# Altium schematic sheet FE.SchDoc
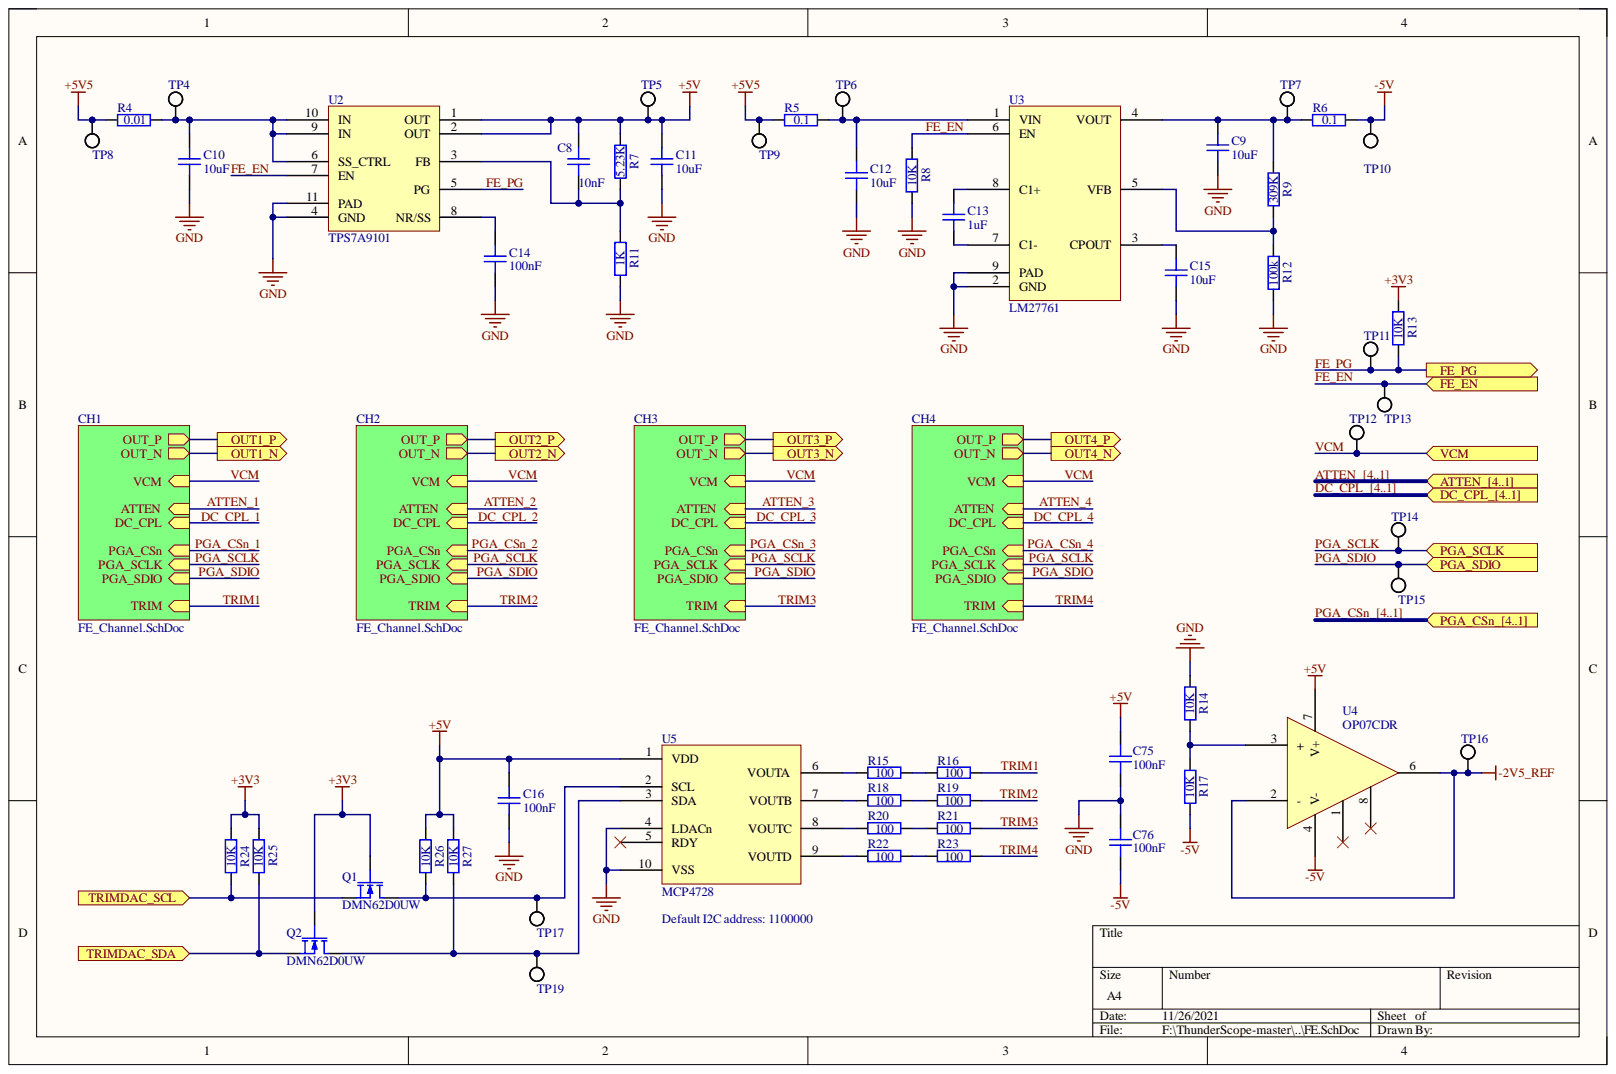
[diagram p0: FE.SchDoc]

## Parts
C8  10nF  [GEN_C_0402]
C9  10uF  [GEN_C_0603]
C10  10uF  [GEN_C_0603]
C11  10uF  [GEN_C_0603]
C12  10uF  [GEN_C_0603]
C13  1uF  [GEN_C_0402]
C14  100nF  [GEN_C_0402]
C15  10uF  [GEN_C_0603]
C16  100nF  [GEN_C_0402]
C75  100nF  [GEN_C_0402]
C76  100nF  [GEN_C_0402]
Q1  DMN62D0UW  [GEN_SOT_323]
Q2  DMN62D0UW  [GEN_SOT_323]
R4  0.01  [GEN_R_0603]
R5  0.1  [GEN_R_0402]
R6  0.1  [GEN_R_0402]
R7  5.23K  [GEN_R_0402]
R8  10K  [GEN_R_0402]
R9  309K  [GEN_R_0402]
R11  1K  [GEN_R_0402]
R12  100k  [GEN_R_0402]
R13  10K  [GEN_R_0402]
R14  10K  [GEN_R_0402]
R15  100  [GEN_R_0402]
R16  100  [GEN_R_0402]
R17  10K  [GEN_R_0402]
R18  100  [GEN_R_0402]
R19  100  [GEN_R_0402]
R20  100  [GEN_R_0402]
R21  100  [GEN_R_0402]
R22  100  [GEN_R_0402]
R23  100  [GEN_R_0402]
R24  10K  [GEN_R_0402]
R25  10K  [GEN_R_0402]
R26  10K  [GEN_R_0402]
R27  10K  [GEN_R_0402]
TP4  PCB  [GEN_TP_PCB]  (value not drawn)  (no BOM)
TP5  PCB  [GEN_TP_PCB]  (value not drawn)  (no BOM)
TP6  PCB  [GEN_TP_PCB]  (value not drawn)  (no BOM)
TP7  PCB  [GEN_TP_PCB]  (value not drawn)  (no BOM)
TP8  PCB  [GEN_TP_PCB]  (value not drawn)  (no BOM)
TP9  PCB  [GEN_TP_PCB]  (value not drawn)  (no BOM)
TP10  PCB  [GEN_TP_PCB]  (value not drawn)  (no BOM)
TP11  PCB  [GEN_TP_PCB]  (value not drawn)  (no BOM)
TP12  PCB  [GEN_TP_PCB]  (value not drawn)  (no BOM)
TP13  PCB  [GEN_TP_PCB]  (value not drawn)  (no BOM)
TP14  PCB  [GEN_TP_PCB]  (value not drawn)  (no BOM)
TP15  PCB  [GEN_TP_PCB]  (value not drawn)  (no BOM)
TP16  PCB  [GEN_TP_PCB]  (value not drawn)  (no BOM)
TP17  PCB  [GEN_TP_PCB]  (value not drawn)  (no BOM)
TP19  PCB  [GEN_TP_PCB]  (value not drawn)  (no BOM)
U2  TPS7A9101  [TI_WSON10_DSK0010A]
U3  LM27761  [TI_WSON8_DSG0008A]
U4  OP07CDR  [TI_SOIC8_D0008A]
U5  MCP4728  [MC_MSOP10_UN]

## Ports
ATTEN_1, ATTEN_2, ATTEN_3, ATTEN_4, ATTEN_[4..1], DC_CPL_1, DC_CPL_2, DC_CPL_3, DC_CPL_4, DC_CPL_[4..1], FE_EN, FE_PG, OUT1_N, OUT1_P, OUT2_N, OUT2_P, OUT3_N, OUT3_P, OUT4_N, OUT4_P, PGA_CSn_1, PGA_CSn_2, PGA_CSn_3, PGA_CSn_4, PGA_CSn_[4..1], PGA_SCLK, PGA_SDIO, TRIMDAC_SCL, TRIMDAC_SDA, VCM

## Nets
+3V3: Q1.2 Q2.2 R13.2 R24.2 R25.2
+5V: C8.2 C11.2 C16.2 C75.1 R7.2 R26.2 R27.2 TP5.1 U2.1(OUT) U2.2(OUT) U4.7(V+) U5.1(VDD)
+5V5: R4.1 R5.1 TP8.1 TP9.1
-2V5_REF: TP16.1 U4.2(-) U4.6
-5V: C76.2 R6.2 R17.1 TP10.1 U4.4(V-)
GND: C9.1 C10.1 C11.1 C12.1 C14.1 C15.1 C16.1 C75.2 C76.1 R8.1 R11.1 R12.1 R14.2 U2.4(GND) U2.11(PAD) U3.2(GND) U3.9(PAD) U5.4(LDACn) U5.10(VSS)
FE_EN: R8.2 TP13.1 U2.7(EN) U3.6(EN) ; port FE_EN
FE_PG: R13.1 TP11.1 U2.5(PG) ; port FE_PG
PGA_SCLK: TP14.1 ; port PGA_SCLK
PGA_SDIO: TP15.1 ; port PGA_SDIO
TRIM1: R16.1
TRIM2: R19.1
TRIM3: R21.1
TRIM4: R23.1
TRIMDAC_SCL: Q1.3 R24.1 ; port TRIMDAC_SCL
TRIMDAC_SDA: Q2.3 R25.1 ; port TRIMDAC_SDA
VCM: TP12.1 ; port VCM
NetC8_1: C8.1 R7.1 R11.2 U2.3(FB)
NetC9_2: C9.2 R6.1 R9.2 TP7.1 U3.4(VOUT)
NetC10_2: C10.2 R4.2 TP4.1 U2.6(SS_CTRL) U2.9(IN) U2.10(IN)
NetC12_2: C12.2 R5.2 TP6.1 U3.1(VIN)
NetC13_1: C13.1 U3.7(C1-)
NetC13_2: C13.2 U3.8(C1+)
NetC14_2: C14.2 U2.8(NR/SS)
NetC15_2: C15.2 U3.3(CPOUT)
NetQ1_1: Q1.1 R26.1 TP17.1 U5.2(SCL)
NetQ2_1: Q2.1 R27.1 TP19.1 U5.3(SDA)
NetR9_1: R9.1 R12.2 U3.5(VFB)
NetR14_1: R14.1 R17.2 U4.3(+)
NetR15_1: R15.1 R16.2
NetR15_2: R15.2 U5.6(VOUTA)
NetR18_1: R18.1 R19.2
NetR18_2: R18.2 U5.7(VOUTB)
NetR20_1: R20.1 R21.2
NetR20_2: R20.2 U5.8(VOUTC)
NetR22_1: R22.1 R23.2
NetR22_2: R22.2 U5.9(VOUTD)
ATTEN_1: ; port ATTEN_[4..1]  [also labelled ATTEN_]
ATTEN_2: ; port ATTEN_[4..1]  [also labelled ATTEN_]
ATTEN_3: ; port ATTEN_[4..1]  [also labelled ATTEN_]
ATTEN_4: ; port ATTEN_[4..1]  [also labelled ATTEN_]
DC_CPL_1: ; port DC_CPL_[4..1]  [also labelled DC_CPL_]
DC_CPL_2: ; port DC_CPL_[4..1]  [also labelled DC_CPL_]
DC_CPL_3: ; port DC_CPL_[4..1]  [also labelled DC_CPL_]
DC_CPL_4: ; port DC_CPL_[4..1]  [also labelled DC_CPL_]
OUT1_N: ; port OUT1_N
OUT1_P: ; port OUT1_P
OUT2_N: ; port OUT2_N
OUT2_P: ; port OUT2_P
OUT3_N: ; port OUT3_N
OUT3_P: ; port OUT3_P
OUT4_N: ; port OUT4_N
OUT4_P: ; port OUT4_P
PGA_CSn_1: ; port PGA_CSn_[4..1]  [also labelled PGA_CSn_]
PGA_CSn_2: ; port PGA_CSn_[4..1]  [also labelled PGA_CSn_]
PGA_CSn_3: ; port PGA_CSn_[4..1]  [also labelled PGA_CSn_]
PGA_CSn_4: ; port PGA_CSn_[4..1]  [also labelled PGA_CSn_]

Not connected: U4 1 8; U5 5(RDY)

## Library data of the parts
C8 = CAP 10nF 50V X7R 0402: Voltage Rating: 50V; Component Kind: Standard; Library Name: DSO.SchLib; Package: 0402; Supplier 1: Digi-Key; Supplier Part Number 1: 490-6351-1-ND; Value: 10nF
C9-C12 C15 = CAP 10uF 10V X5R 0603: Voltage Rating: 10V; Component Kind: Standard; Library Name: DSO.SchLib; Package: 0402; Supplier 1: Digi-Key; Supplier Part Number 1: 490-10474-1-ND; Value: 10uF
C13 = CAP 1uF 25V X5R 0402: Voltage Rating: 25V; Component Kind: Standard; Library Name: DSO.SchLib; Package: 0402; Supplier 1: Digi-Key; Supplier Part Number 1: 490-10017-1-ND; Value: 1uF
C14 C16 C75 C76 = CAP 100nF 16V X7R 0402: Voltage Rating: 16V; Component Kind: Standard; Library Name: DSO.SchLib; Package: 0402; Supplier 1: Digi-Key; Supplier Part Number 1: 490-4759-1-ND; Value: 100nF
Q1 Q2 = NMOS DMN62D0UW: Id: 340mA; Max Power: 320mW; Vdss: 60V; Component Kind: Standard; Library Name: DSO.SchLib; Supplier 1: Digi-Key; Supplier Part Number 1: DMN62D0UW-7DICT-ND
R4 = RES 0.01 OHM 1% 1/4W 0603: Power Rating: 0.25W; Tolerance: 1%; Component Kind: Standard; Library Name: DSO.SchLib; Package: 0603; Supplier 1: Digi-Key; Supplier Part Number 1: 408-1404-1-ND; Value: 0.01
R5 R6 = RES 0.1 OHM 1% 1/6W 0402: Power Rating: 0.16W; Tolerance: 1%; Component Kind: Standard; Library Name: DSO.SchLib; Package: 0402; Supplier 1: Digi-Key; Supplier Part Number 1: P.10AKCT-ND; Value: 0.1
R7 = RES 5.23K OHM 1% 1/16W 0402: Power Rating: 0.0625W; Tolerance: 1%; Component Kind: Standard; Library Name: DSO.SchLib; Package: 0402; Supplier 1: Digi-Key; Supplier Part Number 1: YAG3195CT-ND; Value: 5.23K
R8 R13 R14 R17 R24-R27 = RES 10K OHM 1% 1/10W 0402: Power Rating: 0.1W; Tolerance: 1%; Component Kind: Standard; Library Name: DSO.SchLib; Package: 0402; Supplier 1: Digi-Key; Supplier Part Number 1: P10.0KLCT-ND; Value: 10K
R9 = RES 309K OHM 1% 1/16W 0402: Power Rating: 0.0625W; Tolerance: 1%; Component Kind: Standard; Library Name: DSO.SchLib; Package: 0402; Supplier 1: Digi-Key; Supplier Part Number 1: YAG3108CT-ND; Value: 309K
R11 = RES 1K OHM 1% 1/10W 0402: Power Rating: 0.1W; Tolerance: 1%; Component Kind: Standard; Library Name: DSO.SchLib; Package: 0402; Supplier 1: Digi-Key; Supplier Part Number 1: 311-1.00KLRCT-ND; Value: 1K
R12 = RES 100K OHM 1% 1/10W 0402: Power Rating: 0.1W; Tolerance: 1%; Component Kind: Standard; Library Name: DSO.SchLib; Package: 0402; Supplier 1: Digi-Key; Supplier Part Number 1: 311-100KLRCT-ND; Value: 100k
R15 R16 R18-R23 = RES 100 OHM 1% 1/10W 0402: Power Rating: 0.1W; Tolerance: 1%; Component Kind: Standard; Library Name: DSO.SchLib; Package: 0402; Supplier 1: Digi-Key; Supplier Part Number 1: 2019-RK73H1ETTP1000FCT-ND; Value: 100
TP4-TP17 TP19 = TP PCB 1mm: Library Name: DSO.SchLib
U2 = IC LDO TPS7A9101: Component Kind: Standard; Library Name: DSO.SchLib; Supplier 1: Digi-Key; Supplier Part Number 1: 296-45298-1-ND
U3 = IC CPUMP LM27761: Component Kind: Standard; Library Name: DSO.SchLib; Supplier 1: Digi-Key; Supplier Part Number 1: 296-44379-1-ND
U4 = IC OPAMP OP07CDR: Component Kind: Standard; Library Name: DSO.SchLib; Supplier 1: Digi-Key; Supplier Part Number 1: 296-14626-1-ND
U5 = IC DAC QUAD MCP4728: Component Kind: Standard; Library Name: DSO.SchLib; Supplier 1: Digi-Key; Supplier Part Number 1: MCP4728A1T-E/UNCT-ND
